# Altium schematic sheet pixhawk_fmuv5.SchDoc
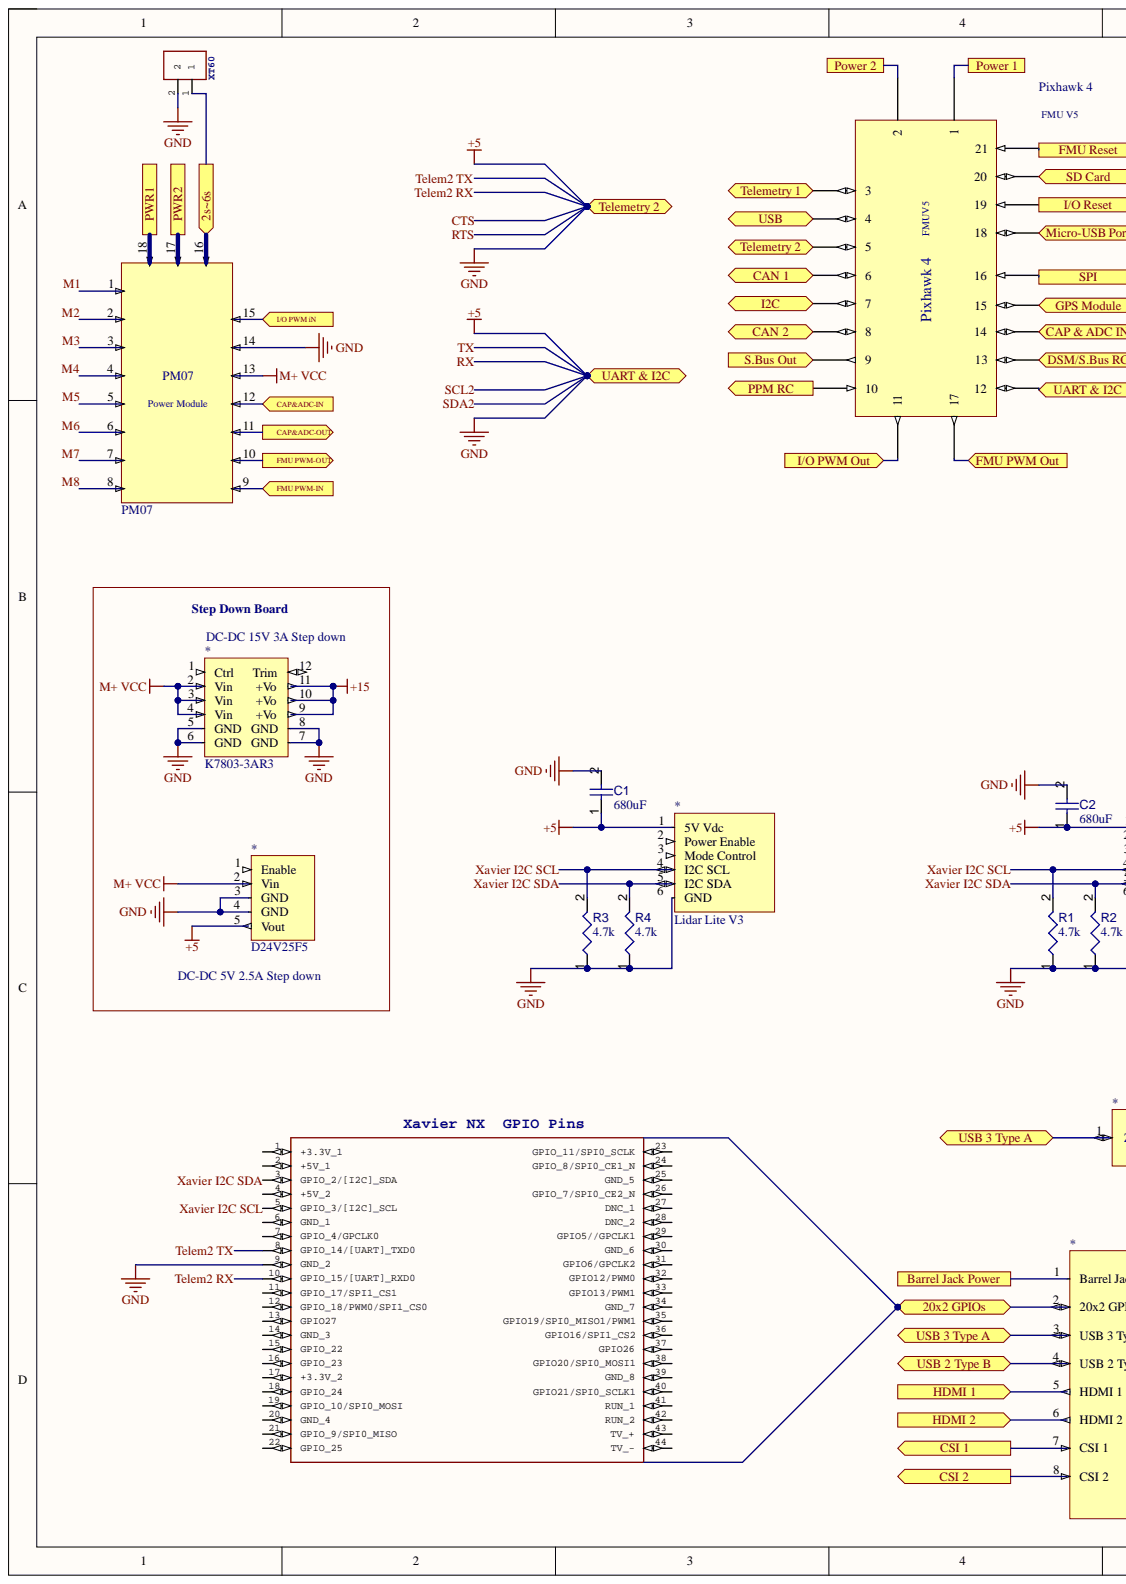
[diagram p0: pixhawk_fmuv5.SchDoc, left]
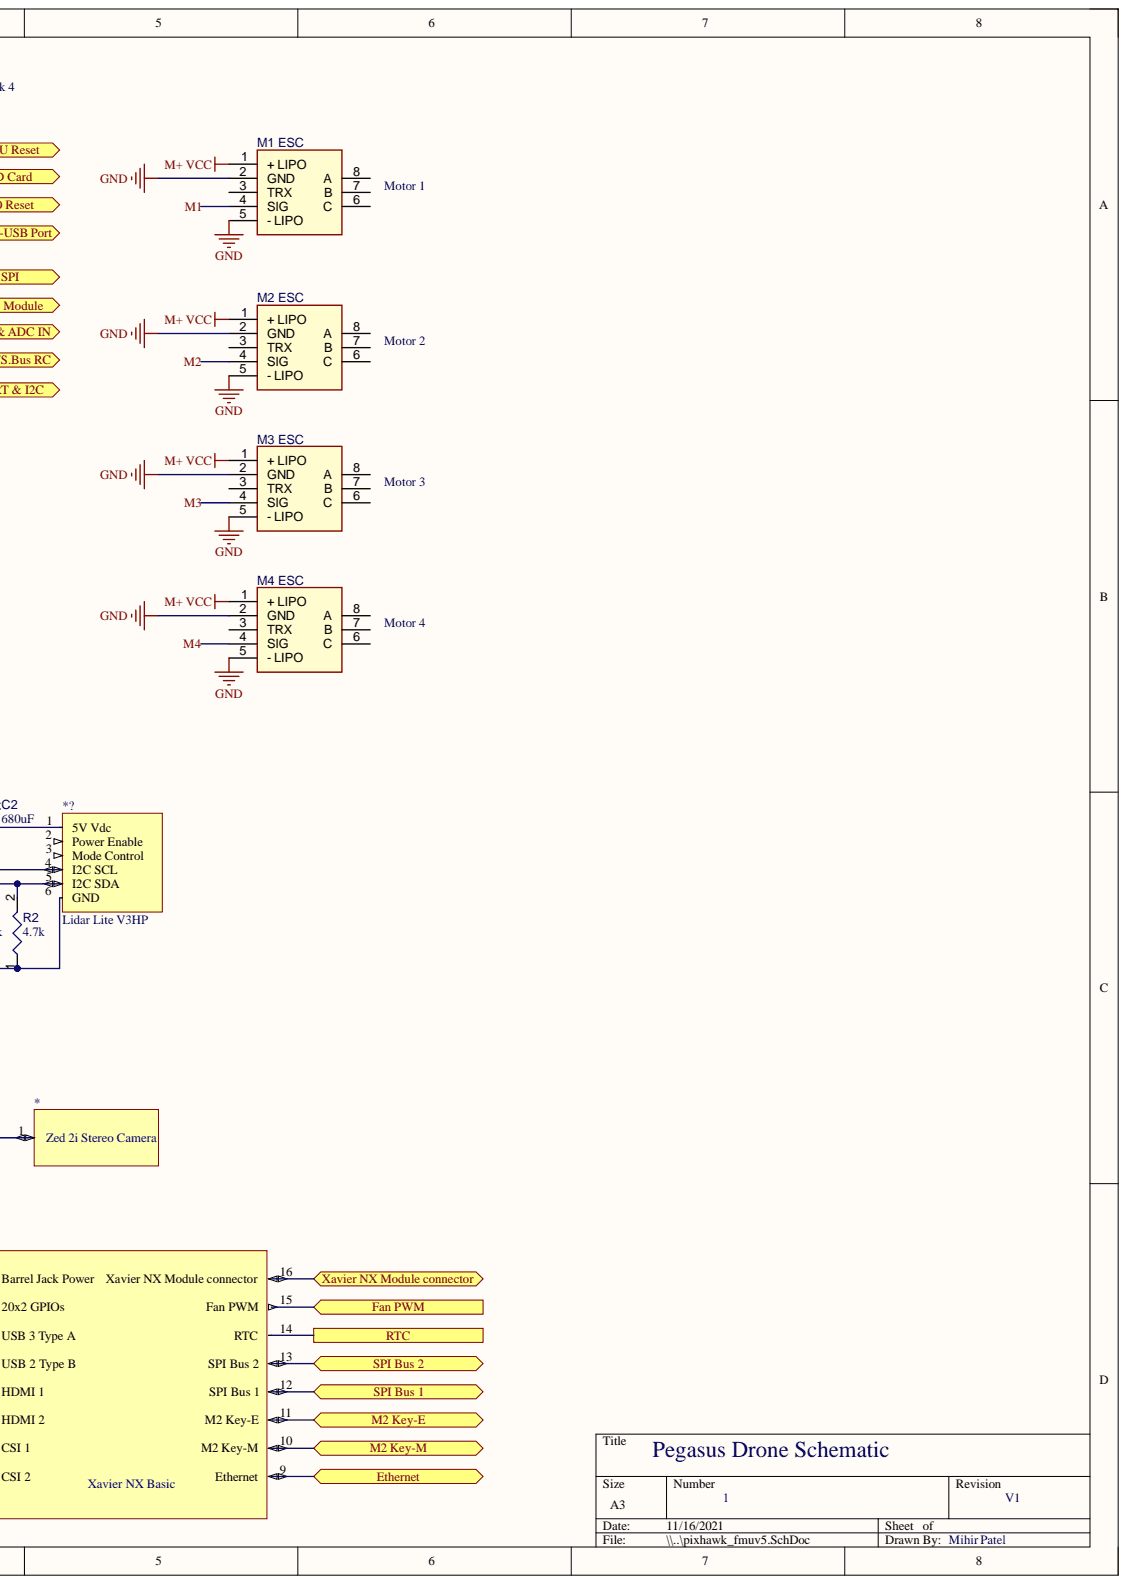
[diagram p1: pixhawk_fmuv5.SchDoc, right]

## Parts
*  Xavier NX Basic
*  D24V25F5
*  K7803-3AR3
*  Zed 2i Stereo Camera
*  Lidar Lite V3
*?  Lidar Lite V3HP
C1  value 680uF  [CAPC0402(1005)33_L]  (hidden comment: Capacitor 680pF +/-20% 50V 0402)
C2  value 680uF  [CAPC0402(1005)33_L]  (hidden comment: Capacitor 680pF +/-20% 50V 0402)
FMU_V5  Pixhawk 4
M1_ESC  KISS_ESC_2-6S_32A  [ESC 2-6S 32A-Footprint-1]  (value not drawn)
M2_ESC  KISS_ESC_2-6S_32A  [ESC 2-6S 32A-Footprint-1]  (value not drawn)
M3_ESC  KISS_ESC_2-6S_32A  [ESC 2-6S 32A-Footprint-1]  (value not drawn)
M4_ESC  KISS_ESC_2-6S_32A  [ESC 2-6S 32A-Footprint-1]  (value not drawn)
PM07  PM07 Power Module
R1  value 4.7k  [RESC3116X70X50ML13T20]  (hidden comment: Resistor 4k7 +/-1% 1206 250 mW)
R2  value 4.7k  [RESC3116X70X50ML13T20]  (hidden comment: Resistor 4k7 +/-1% 1206 250 mW)
R3  value 4.7k  [RESC3116X70X50ML13T20]  (hidden comment: Resistor 4k7 +/-1% 1206 250 mW)
R4  value 4.7k  [RESC3116X70X50ML13T20]  (hidden comment: Resistor 4k7 +/-1% 1206 250 mW)
Xavier_NX_GPIO_Pins  Raspberry Pi Zero W (v1.3) Single-board Computers   Source:  Datasheet  [RASPBERRYPIZEROWV13]  (value not drawn)
XT60  Connector 2 pins  [AMASS_XT60PB-M]  (value not drawn)

## Ports
2s~6s, 20x2 GPIOs, Barrel Jack Power, CAN 1, CAN 2, CAP & ADC IN, CAP&ADC-IN, CAP&ADC-OUT, CSI 1, CSI 2, DSM/S.Bus RC, Ethernet, Fan PWM, FMU PWM Out, FMU PWM-IN, FMU PWM-OUT, FMU Reset, GPS Module, HDMI 1, HDMI 2, I2C, I/O PWM iN, I/O PWM Out, I/O Reset, M2 Key-E, M2 Key-M, Micro-USB Port, Power 1, Power 2, PPM RC, PWR1, PWR2, RTC, S.Bus Out, SD Card, SPI, SPI Bus 1, SPI Bus 2, Telemetry 1, Telemetry 2, UART & I2C, USB, USB 2 Type B, USB 3 Type A, Xavier NX Module connector

## Nets
+15: *.9 *.10 *.11
M+ VCC: *.2 *.3 *.4 M1_ESC.1(+_LIPO) M2_ESC.1(+_LIPO) M3_ESC.1(+_LIPO) M4_ESC.1(+_LIPO) PM07.13
2s~6s: XT60.1 ; port 2s~6s
20x2 GPIOs: *.2 ; port 20x2 GPIOs
Barrel Jack Power: *.1 *.3 ; port Barrel Jack Power, port USB 3 Type A
CAN 1: FMU_V5.6 ; port CAN 1
CAN 2: FMU_V5.8 ; port CAN 2
CAP & ADC IN: FMU_V5.14 ; port CAP & ADC IN
CAP&ADC-IN: PM07.12 ; port CAP&ADC-IN
CAP&ADC-OUT: PM07.11 ; port CAP&ADC-OUT
CSI 1: *.7 ; port CSI 1
CSI 2: *.8 ; port CSI 2
CTS: *.1 *.3 *.4 *.5 *.6 *.7 *.8 *?.1(5V_Vdc) *?.6(GND) C1.1 C1.2 C2.1 C2.2 FMU_V5.5 FMU_V5.12 M1_ESC.2(GND) M1_ESC.5(-_LIPO) M2_ESC.2(GND) M2_ESC.5(-_LIPO) M3_ESC.2(GND) M3_ESC.5(-_LIPO) M4_ESC.2(GND) M4_ESC.5(-_LIPO) PM07.14 R1.1 R2.1 R3.1 R4.1 Xavier_NX_GPIO_Pins.8(GPIO_14/[UART]_TXD0) Xavier_NX_GPIO_Pins.9(GND_2) Xavier_NX_GPIO_Pins.10(GPIO_15/[UART]_RXD0) XT60.2 ; port Telemetry 2, port UART & I2C
DSM/S.Bus RC: FMU_V5.13 ; port DSM/S.Bus RC
Ethernet: *.9 ; port Ethernet
Fan PWM: *.15(Fan_PWM) ; port Fan PWM
FMU PWM Out: FMU_V5.17 ; port FMU PWM Out
FMU PWM-IN: PM07.9 ; port FMU PWM-IN
FMU PWM-OUT: PM07.10 ; port FMU PWM-OUT
FMU Reset: FMU_V5.21 ; port FMU Reset
GPS Module: FMU_V5.15 ; port GPS Module
HDMI 1: *.5 ; port HDMI 1
HDMI 2: *.6 ; port HDMI 2
I2C: FMU_V5.7 ; port I2C
I/O PWM iN: PM07.15 ; port I/O PWM iN
I/O PWM Out: FMU_V5.11 ; port I/O PWM Out
I/O Reset: FMU_V5.19 ; port I/O Reset
M1: M1_ESC.4(SIG) PM07.1
M2: M2_ESC.4(SIG) PM07.2
M2 Key-E: *.11 ; port M2 Key-E
M2 Key-M: *.10 ; port M2 Key-M
M3: M3_ESC.4(SIG) PM07.3
M4: M4_ESC.4(SIG) PM07.4
M5: PM07.5
M6: PM07.6
M7: PM07.7
M8: PM07.8
Micro-USB Port: FMU_V5.18 ; port Micro-USB Port
Power 1: FMU_V5.1 ; port Power 1
Power 2: FMU_V5.2 ; port Power 2
PPM RC: FMU_V5.10 ; port PPM RC
RTC: *.14(RTC) ; port RTC
S.Bus Out: FMU_V5.9 ; port S.Bus Out
SD Card: FMU_V5.20 ; port SD Card
SPI: FMU_V5.16 ; port SPI
SPI Bus 1: *.12 ; port SPI Bus 1
SPI Bus 2: *.13(SPI_Bus_2) ; port SPI Bus 2
Telemetry 1: FMU_V5.3 ; port Telemetry 1
USB: FMU_V5.4 ; port USB
USB 2 Type B: *.4 ; port USB 2 Type B
Xavier I2C SCL: *.4 *?.4(I2C_SCL) R1.2 R3.2 Xavier_NX_GPIO_Pins.5(GPIO_3/[I2C]_SCL)
Xavier I2C SDA: *.5 *?.5(I2C_SDA) R2.2 R4.2 Xavier_NX_GPIO_Pins.3(GPIO_2/[I2C]_SDA)
Xavier NX Module connector: *.16(Module_connector) ; port Xavier NX Module connector
N00001: ; port PWR2
N00002: ; port PWR1

Not connected: *? 2(Power_Enable) 3(Mode_Control); M1_ESC 3(TRX) 6(C) 7(B) 8(A); M2_ESC 3(TRX) 6(C) 7(B) 8(A); M3_ESC 3(TRX) 6(C) 7(B) 8(A); M4_ESC 3(TRX) 6(C) 7(B) 8(A); PM07 16 17 18; Xavier_NX_GPIO_Pins 1(+3.3V_1) 2(+5V_1) 4(+5V_2) 6(GND_1) 7(GPIO_4/GPCLK0) 11(GPIO_17/SPI1_CS1) 12(GPIO_18/PWM0/SPI1_CS0) 13(GPIO27) 14(GND_3) 15(GPIO_22) 16(GPIO_23) 17(+3.3V_2) 18(GPIO_24) 19(GPIO_10/SPI0_MOSI) 20(GND_4) 21(GPIO_9/SPI0_MISO) 22(GPIO_25) 23(GPIO_11/SPI0_SCLK) 24(GPIO_8/SPI0_CE1_N) 25(GND_5) 26(GPIO_7/SPI0_CE2_N) 27(DNC_1) 28(DNC_2) 29(GPIO5//GPCLK1) 30(GND_6) 31(GPIO6/GPCLK2) 32(GPIO12/PWM0) 33(GPIO13/PWM1) 34(GND_7) 35(GPIO19/SPI0_MISO1/PWM1) 36(GPIO16/SPI1_CS2) 37(GPIO26) 38(GPIO20/SPI0_MOSI1) 39(GND_8) 40(GPIO21/SPI0_SCLK1) 41(RUN_1) 42(RUN_2) 43(TV_+) 44(TV_-)

## Library data of the parts
* = CMP-00028-00015-2: Basic Figure of Xavier NX; Component Kind: Standard; Library Name: Northwestern University
* = CMP-00028-00014-1: Step down 5V 2.5 A; Component Kind: Standard; Library Name: Northwestern University
* = CMP-00028-00013-1: DC DC Converter 15V; Component Kind: Standard; Library Name: Northwestern University
* = CMP-00028-00010-1: Component Kind: Standard; Library Name: Northwestern University
* *? = CMP-00028-00011-2: Component Kind: Standard; Library Name: Northwestern University
C1 C2 = CMP-001-00070-3: Chip Capacitor, 680pF +/-20%, 50V, 0402, Thickness 0.33 mm; Tolerance: 10%; Case/Package: 0402; Component Kind: Standard; Max Operating Temperature: 85°C; Min Operating Temperature: -55°C; Mounting Technology: SMT; Pins: 2; Voltage Rating: 50V; Library Name: Northwestern University
FMU_V5 = CMP-00028-00012-2: Component Kind: Standard; Library Name: Northwestern University
M1_ESC M2_ESC M3_ESC M4_ESC = CMP-00030-00000-2: KISS ESC 2-6S 32A (45A limit) - 32bit brushless Motor Ctrl; Component Kind: Standard; Pins: 8; Library Name: Northwestern University
PM07 = CMP-00028-00007-2: Component Kind: Standard; Library Name: Northwestern University
R1-R4 = CMP-009-00033-5: Chip Resistor, 4.7 KOhm, +/- 1%, 0.25 W, -55 to 155 degC, 1206 (3216 Metric); Power: 250mW; Voltage Rating: 200V; Case/Package: 1206; Component Kind: Standard; Max Operating Temperature: 155°C; Min Operating Temperature: -55°C; Mounting Technology: SM; Pins: 2; RoHS Compliant: True; Tolerance: 1%; Library Name: Northwestern University
Xavier_NX_GPIO_Pins = RASPBERRY_PI_ZERO_W_(V1.3): Manufacturer_Name: RASPBERRY-PI; GateName_1: G$1; Component Kind: Standard; DeviceSetName: RASPBERRY_PI_ZERO_W_(V1.3); Height: mm; Library Name: Raspberry_Pi_Zero_W_(v1.3).IntLib; LibraryName: Raspberry_Pi_Zero_W_(v1.3); Manufacturer_Part_Number: Raspberry Pi Zero W (v1.3); Package: RASPBERRYPIZEROWV13; SymbolName_1: RASPBERRY_PI_ZERO_W_(V1.3)
XT60 = XT60_YELLOW: GateName_1: G$1; MF: Pololu; MP: XT60 YELLOW; Availability: Unavailable; Component Kind: Standard; DeviceSetName: XT60_YELLOW; Library Name: XT60_YELLOW.IntLib; LibraryName: XT60_YELLOW; Package: AMASS_XT60PB-M; Price: None; SymbolName_1: XT60_YELLOW
